# Altium schematic sheet 4-20mA Transmitter-XTR117.SCHDOC
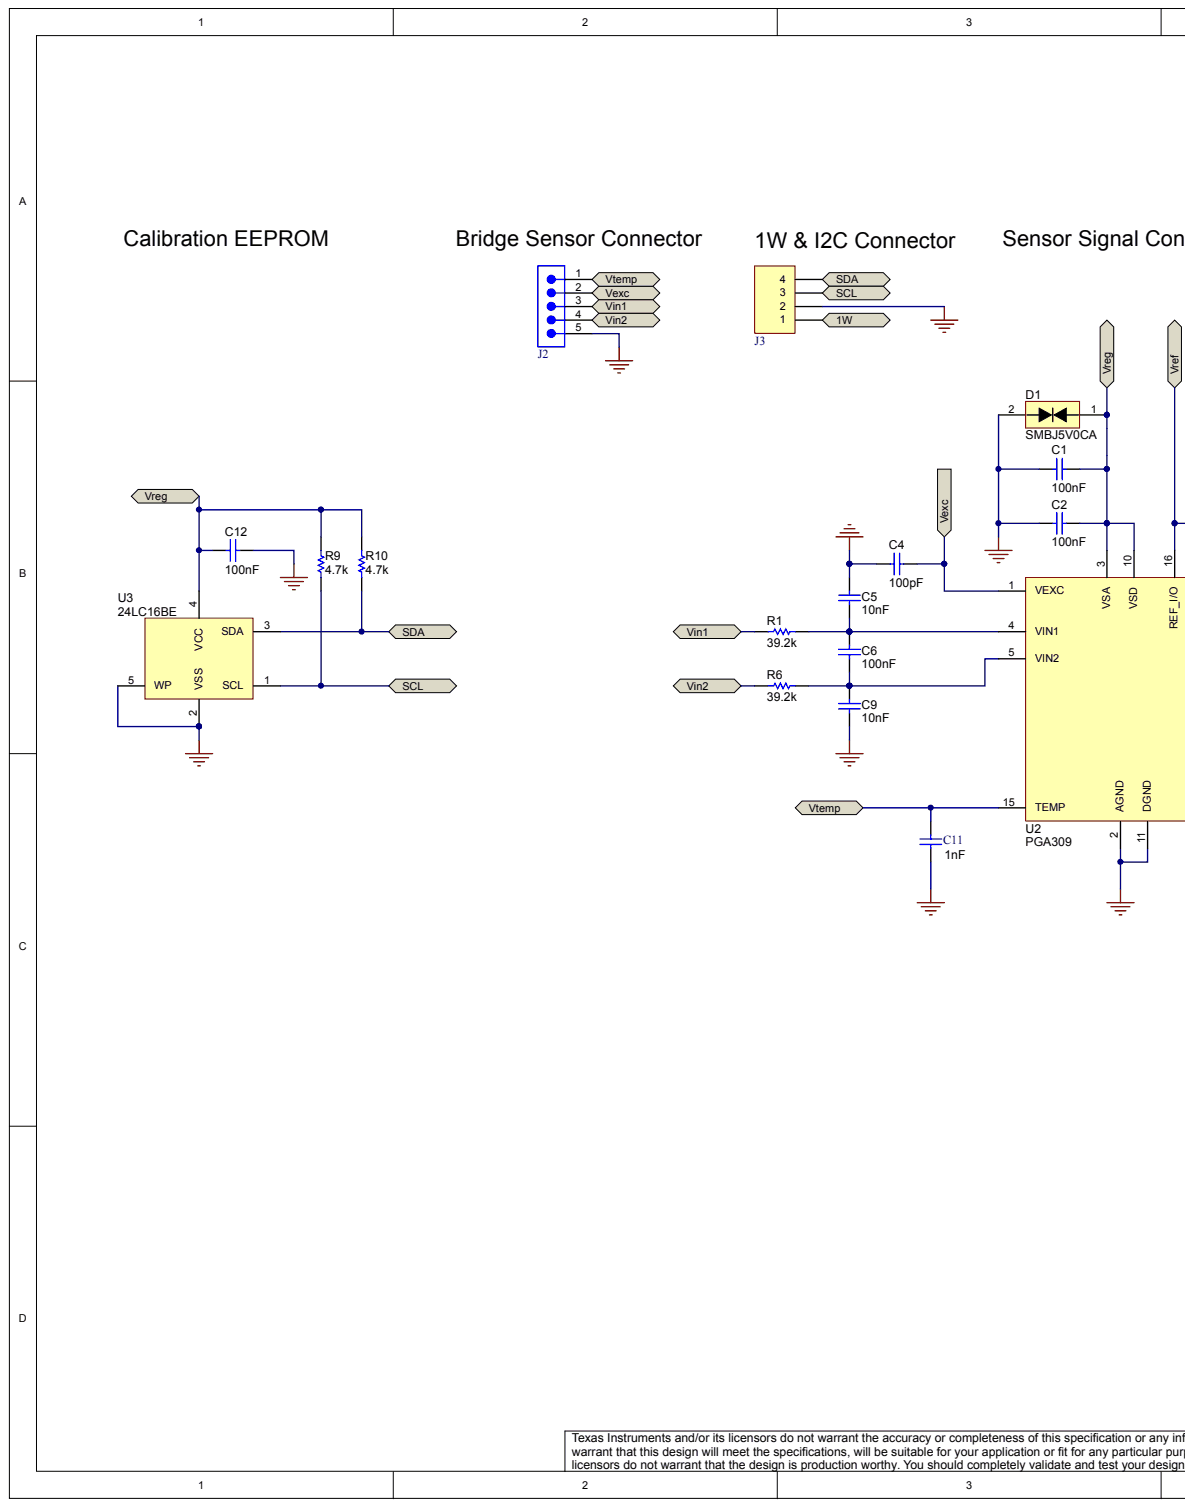
[diagram p0: 4-20mA Transmitter-XTR117.SCHDOC, left]
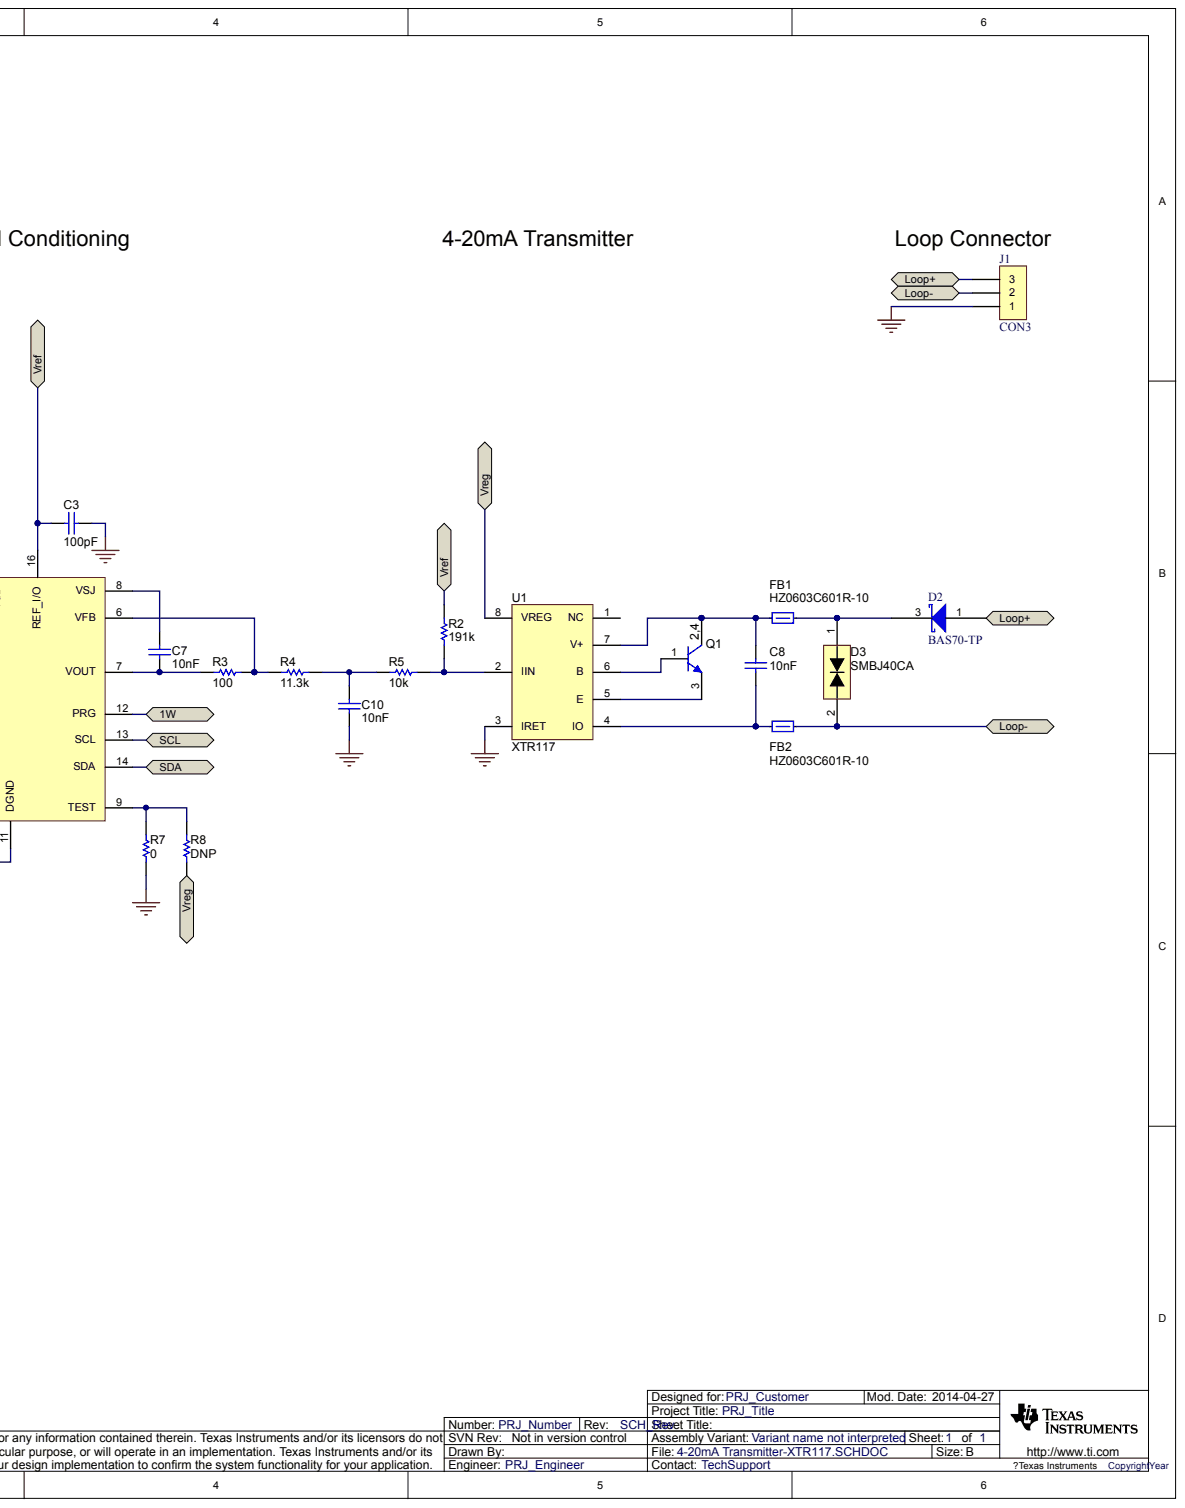
[diagram p1: 4-20mA Transmitter-XTR117.SCHDOC, right]

## Parts
C1  value 100nF  (hidden comment: Used in BOM report)
C2  value 100nF  (hidden comment: Used in BOM report)
C3  value 100pF  (hidden comment: Used in BOM report)
C4  value 100pF  (hidden comment: Used in BOM report)
C5  value 10nF  (hidden comment: Used in BOM report)
C6  value 100nF  (hidden comment: Used in BOM report)
C7  value 10nF  (hidden comment: Used in BOM report)
C8  value 10nF  (hidden comment: Used in BOM report)
C9  value 10nF  (hidden comment: Used in BOM report)
C10  value 10nF  (hidden comment: Used in BOM report)
C11  value 1nF  (hidden comment: Used in BOM report)
C12  value 100nF  (hidden comment: Used in BOM report)
D1  SMBJ5V0CA
D2  BAS70-TP
D3  SMBJ40CA
FB1  value HZ0603C601R-10  (hidden comment: Used in BOM report)
FB2  value HZ0603C601R-10  (hidden comment: Used in BOM report)
J1  CON3
J2  TSW-105-07-G-S  (value not drawn)
J3  1W & I2C Connector
Q1  FCX690BTA  (value not drawn)
R1  value 39.2k  (hidden comment: Used in BOM report)
R2  value 191k  (hidden comment: Used in BOM report)
R3  value 100  (hidden comment: Used in BOM report)
R4  value 11.3k  (hidden comment: Used in BOM report)
R5  value 10k  (hidden comment: Used in BOM report)
R6  value 39.2k  (hidden comment: Used in BOM report)
R7  value 0  (hidden comment: Used in BOM report)
R8  value DNP  (hidden comment: Used in BOM report)
R9  value 4.7k  (hidden comment: Used in BOM report)
R10  value 4.7k  (hidden comment: Used in BOM report)
U1  XTR117
U2  PGA309
U3  24LC16BE

## Ports
1W, LOOP+, LOOP-, SCL, SDA, VEXC, VIN1, VIN2, VREF, VREG, VTEMP

## Nets
GND: C1.1 C2.1 C3.2 C4.1 C5.1 C9.2 C10.2 C11.2 C12.2 D1.2 J1.1 J2.5 J3.2 R7.1 U1.3(IRET) U2.2(AGND) U2.11(DGND) U3.2(VSS) U3.5(WP)
1W: J3.1 U2.12(PRG) ; port 1W
SCL: J3.3 R9.1 U2.13(SCL) U3.1(SCL) ; port SCL
SDA: J3.4 R10.1 U2.14(SDA) U3.3(SDA) ; port SDA
NetC1_2: C1.2 C2.2 C12.1 D1.1 R8.1 R9.2 R10.2 U1.8(VREG) U2.3(VSA) U2.10(VSD) U3.4(VCC) ; port VREG
NetC3_1: C3.1 R2.1 U2.16(REF_I/O) ; port VREF
NetC4_2: C4.2 J2.2 U2.1(VEXC) ; port VEXC
NetC5_2: C5.2 C6.1 R1.2 U2.4(VIN1)
NetC6_2: C6.2 C9.1 R6.2 U2.5(VIN2)
NetC7_1: C7.1 U2.8(VSJ)
NetC7_2: C7.2 R3.2 U2.7(VOUT)
NetC8_1: C8.1 FB1.1 Q1.2 U1.7(V+)
NetC8_2: C8.2 FB2.1 U1.4(IO)
NetC10_1: C10.1 R4.1 R5.2
NetC11_1: C11.1 J2.1 U2.15(TEMP) ; port VTEMP
NetD2_1: D2.1 J1.3
NetD2_3: D2.3 D3.1 FB1.2
NetD3_2: D3.2 FB2.2 J1.2
NetJ2_3: J2.3 R1.1 ; port VIN1
NetJ2_4: J2.4 R6.1 ; port VIN2
NetQ1_1: Q1.1 U1.6(B)
NetQ1_3: Q1.3 U1.5(E)
NetR2_2: R2.2 R5.1 U1.2(IIN)
NetR3_1: R3.1 R4.2 U2.6(VFB)
NetR7_2: R7.2 R8.2 U2.9(TEST)

Not connected: U1 1(NC)

## Library data of the parts
C1-C12 = (no symbol name): Polarized: N; CompType: Capacitor; U/M: EA; SymbolVersion: 2008-07-09; PackageReference: Used in PnP output; Manufacturer: Used in BOM report; PartNumber: Used in BOM report; RoHS: Used in BOM report.  Use Y or N.; MountType: SMT or TH (used in PnP report); ComponentLink1Description: Datasheet Link; ComponentLink1URL: Paste URL for datasheet here; LatestRevisionNote: Added Alternate PartNumber and Alternate Manufacturer parameters to appropriate components.; Alternate Manufacturer: -; Alternate PartNumber: -; Publisher: Texas Instruments
  C1-C10 C12: LatestRevisionDate: 2012-08-27
  C11: LatestRevisionDate: 2012-11-28
D1 D3 U1-U3 = (no symbol name): Manufacturer: Used in BOM report; SymbolVersion: use current date i.e. 2008-05-20; SymbolCreator: Use a-id; CompType: Used in some BOM reports.  Useful for finding later.; U/M: EA, IN, or GM; MountType: SMT, TH, or N/A; RoHS: Used in BOM report.  Use Y or N.; PartNumber: Used in BOM report; PackageReference: eg:  0603, used in PnP report; ComponentLink1Description: Datasheet Link; Alternate PartNumber: -; Alternate Manufacturer: -
FB1 FB2 = (no symbol name): U/M: EA; CompType: Used in BOM report; SymbolVersion: 2009-06-02; PackageReference: Used in PnP output; Manufacturer: Used in BOM report; PartNumber: Used in BOM report; RoHS: Used in BOM report.  Use Y or N.; MountType: SMT or TH (used in PnP report); ComponentLink1Description: Datasheet Link; ComponentLink1URL: Paste URL for datasheet here; LatestRevisionDate: 2012-08-27; LatestRevisionNote: Added Alternate PartNumber and Alternate Manufacturer parameters to appropriate components.; Alternate Manufacturer: -; Alternate PartNumber: -; Publisher: Texas Instruments
J2 = (no symbol name): Manufacturer: Samtec, Inc.; CompType: Header; U/M: EA; PartNumber: TSW-105-07-G-S; ClassName: Header; RoHS: Y; PackageReference: TSW-105-07-G-S; MountType: TH; ComponentLink1Description: Datasheet Link; ComponentLink1URL: http://samtec.com/ftppub/pdf/TSW_TH.PDF; LatestRevisionDate: 2013-01-15; LatestRevisionNote: Added parts, moved some to Hardware.IntLib; Supplier 1: Digi-Key; Supplier Part Number 1: SAM1029-05-ND; Publisher: Texas Instruments
Q1 = (no symbol name): Manufacturer: Diodes Inc.; Alternate Manufacturer: None; CompType: BJT; U/M: EA; PNP: 0; NPN: 1; Vceo_V: 45; Vcesat1_V: 0.08; Value: 0.08V; Value1: 1A; SymbolVersion: 2009-09-01; MountType: SMT; PartNumber: FCX690BTA; PackageReference: SOT-89; RoHS: Y; IcRated_A: 1; Published: 2008-07-31; LatestRevisionDate: 2012-07-20; LatestRevisionNote: Removed DigiKeyPartNumber parameter.; ComponentLink1Description: Datasheet link; ComponentLink1URL: http://www.diodes.com/datasheets/FCX690B.pdf; Alternate PartNumber: None; Supplier 1: Digi-Key; Supplier Part Number 1: FCX690BCT-ND; Supplier 2: Arrow; Supplier Part Number 2: FCX690BTA; Publisher: Texas Instruments
R1-R10 = (no symbol name): CompType: Resistor; U/M: EA; SymbolVersion: 2009-05-29; PackageReference: Used in PnP output; Manufacturer: Used in BOM report; PartNumber: Used in BOM report; RoHS: Used in BOM report.  Use Y or N.; MountType: SMT or TH (used in PnP report); ComponentLink1Description: Datasheet Link; ComponentLink1URL: Paste URL for datasheet here; LatestRevisionDate: 2012-08-27; LatestRevisionNote: Added Alternate PartNumber and Alternate Manufacturer parameters to appropriate components.; Alternate Manufacturer: -; Alternate PartNumber: -; Publisher: Texas Instruments
D2 J1 J3 = (no symbol name)
  J3: LatestRevisionDate: 17-Jul-2002; LatestRevisionNote: Re-released for DXP Platform.; Publisher: Altium Limited
